# Altium schematic sheet Fly_Module.SchDoc(U_Fly_Module)
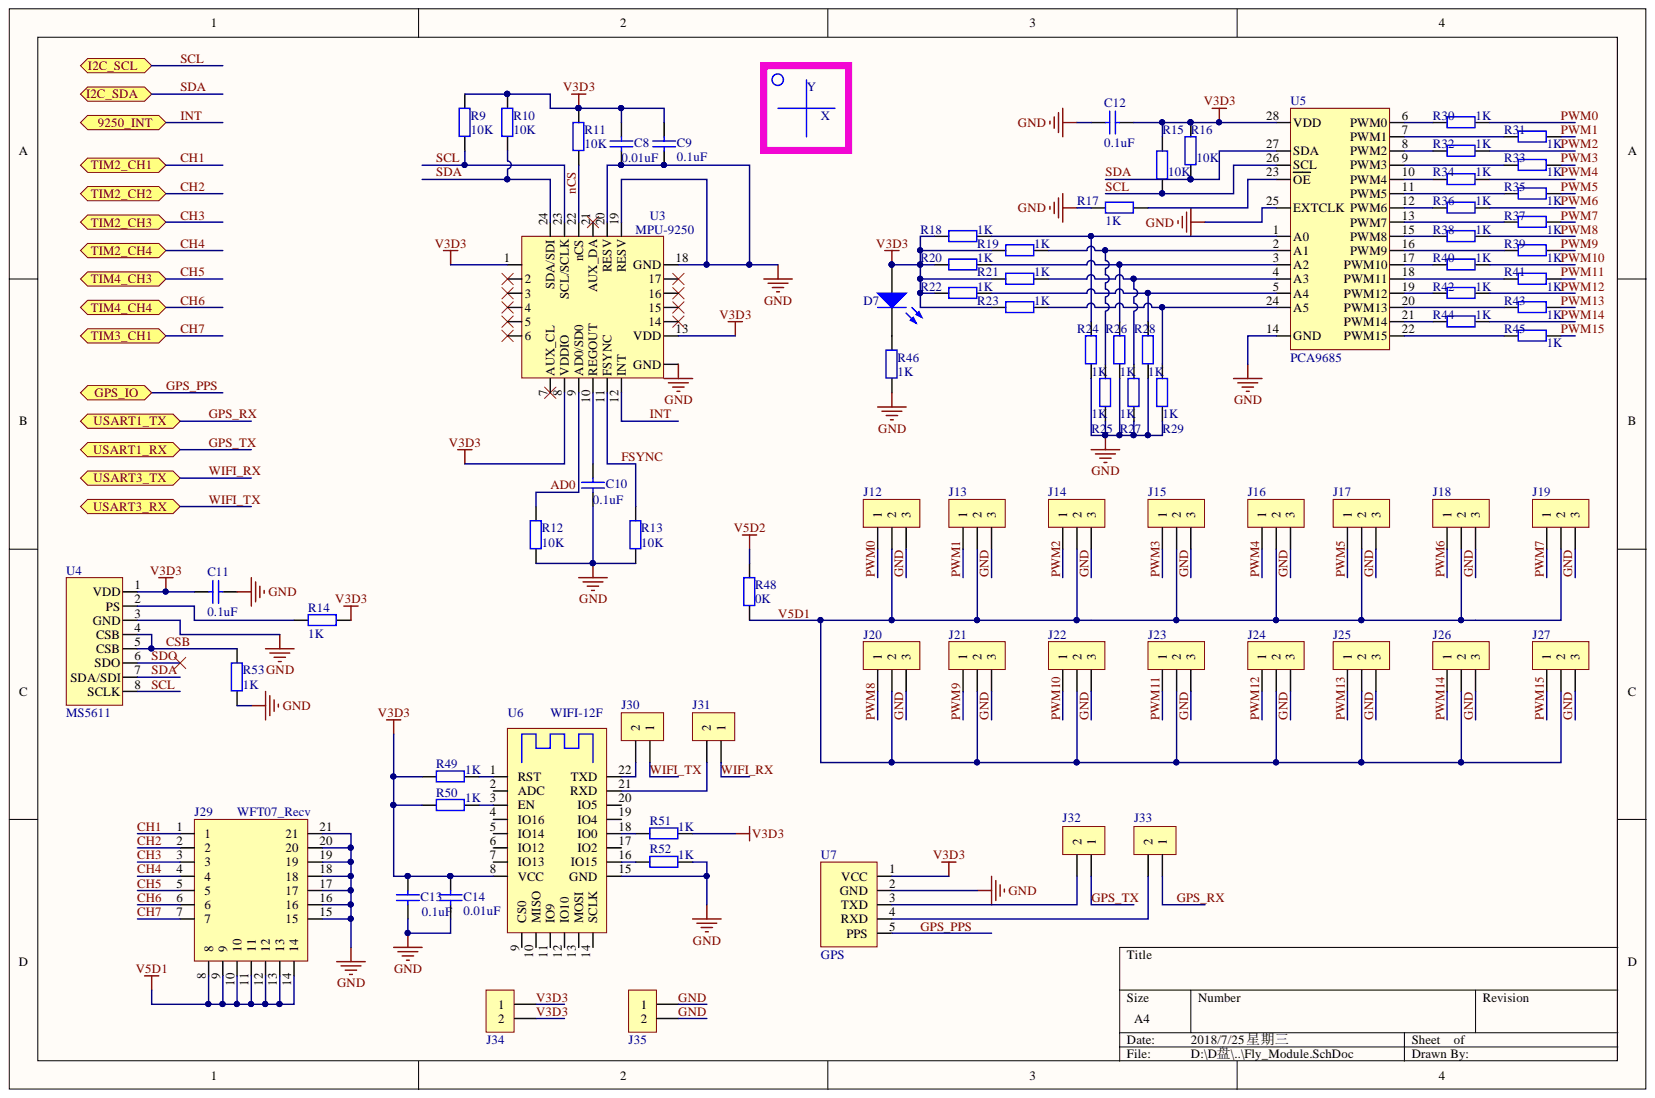
[diagram p0: Fly_Module.SchDoc]

## Parts
C8  value 0.01uF  [0603]  (hidden comment: Cap)
C9  value 0.1uF  [0603]  (hidden comment: Cap)
C10  value 0.1uF  [0603]  (hidden comment: Cap)
C11  value 0.1uF  [0603]  (hidden comment: Cap)
C12  value 0.1uF  [0603]  (hidden comment: Cap)
C13  value 0.1uF  [0603]  (hidden comment: Cap)
C14  value 0.01uF  [0603]  (hidden comment: Cap)
D7  D*  [SIP-2.54-1x2]
J12  Header1X3  [SIP-2.54-1x3]  (value not drawn)
J13  Header1X3  [SIP-2.54-1x3]  (value not drawn)
J14  Header1X3  [SIP-2.54-1x3]  (value not drawn)
J15  Header1X3  [SIP-2.54-1x3]  (value not drawn)
J16  Header1X3  [SIP-2.54-1x3]  (value not drawn)
J17  Header1X3  [SIP-2.54-1x3]  (value not drawn)
J18  Header1X3  [SIP-2.54-1x3]  (value not drawn)
J19  Header1X3  [SIP-2.54-1x3]  (value not drawn)
J20  Header1X3  [SIP-2.54-1x3]  (value not drawn)
J21  Header1X3  [SIP-2.54-1x3]  (value not drawn)
J22  Header1X3  [SIP-2.54-1x3]  (value not drawn)
J23  Header1X3  [SIP-2.54-1x3]  (value not drawn)
J24  Header1X3  [SIP-2.54-1x3]  (value not drawn)
J25  Header1X3  [SIP-2.54-1x3]  (value not drawn)
J26  Header1X3  [SIP-2.54-1x3]  (value not drawn)
J27  Header1X3  [SIP-2.54-1x3]  (value not drawn)
J29  WFT07_Recv  [Header3x7]
J30  Header1X2  [SIP-2.54-1x2]  (value not drawn)
J31  Header1X2  [SIP-2.54-1x2]  (value not drawn)
J32  Header1X2  [SIP-2.54-1x2]  (value not drawn)
J33  Header1X2  [SIP-2.54-1x2]  (value not drawn)
J34  Header1X2  [SIP-2.54-1x2]  (value not drawn)
J35  Header1X2  [SIP-2.54-1x2]  (value not drawn)
R9  R*  value 10K  [0603]
R10  R*  value 10K  [0603]
R11  R*  value 10K  [0603]
R12  R*  value 10K  [0603]
R13  R*  value 10K  [0603]
R14  R*  value 1K  [0603]
R15  R*  value 10K  [0603]
R16  R*  value 10K  [0603]
R17  R*  value 1K  [0603]
R18  R*  value 1K  [0603]
R19  R*  value 1K  [0603]
R20  R*  value 1K  [0603]
R21  R*  value 1K  [0603]
R22  R*  value 1K  [0603]
R23  R*  value 1K  [0603]
R24  R*  value 1K  [0603]
R25  R*  value 1K  [0603]
R26  R*  value 1K  [0603]
R27  R*  value 1K  [0603]
R28  R*  value 1K  [0603]
R29  R*  value 1K  [0603]
R30  R*  value 1K  [0603]
R31  R*  value 1K  [0603]
R32  R*  value 1K  [0603]
R33  R*  value 1K  [0603]
R34  R*  value 1K  [0603]
R35  R*  value 1K  [0603]
R36  R*  value 1K  [0603]
R37  R*  value 1K  [0603]
R38  R*  value 1K  [0603]
R39  R*  value 1K  [0603]
R40  R*  value 1K  [0603]
R41  R*  value 1K  [0603]
R42  R*  value 1K  [0603]
R43  R*  value 1K  [0603]
R44  R*  value 1K  [0603]
R45  R*  value 1K  [0603]
R46  R*  value 1K  [0603]
R48  R*  value 0K  [0603]
R49  R*  value 1K  [0603]
R50  R*  value 1K  [0603]
R51  R*  value 1K  [0603]
R52  R*  value 1K  [0603]
R53  R*  value 1K  [0603]
U3  MPU-9250  [MPU9250]
U4  MS5611  [MS5611]
U5  PCA9685  [PCA9685]
U6  WIFI-12F  [ESP-12F]
U7  GPS  [GPS]

## Ports
9250_INT, GPS_IO, I2C_SCL, I2C_SDA, TIM2_CH1, TIM2_CH2, TIM2_CH3, TIM2_CH4, TIM3_CH1, TIM4_CH3, TIM4_CH4, USART1_RX, USART1_TX, USART3_RX, USART3_TX

## Nets
V5D2: R48.1
AD0: R12.2 U3.9(AD0/SD0)
CH1: J29.1 ; port TIM2_CH1
CH2: J29.2 ; port TIM2_CH2
CH3: J29.3 ; port TIM2_CH3
CH4: J29.4 ; port TIM2_CH4
CH5: J29.5 ; port TIM4_CH3
CH6: J29.6 ; port TIM4_CH4
CH7: J29.7 ; port TIM3_CH1
CSB: R53.2 U4.4(CSB) U4.5(CSB)
FSYNC: R13.2 U3.11(FSYNC)
GND: C8.1 C9.1 C10.1 C11.1 C12.2 C13.2 C14.2 J12.3 J13.3 J14.3 J15.3 J16.3 J17.3 J18.3 J19.3 J20.3 J21.3 J22.3 J23.3 J24.3 J25.3 J26.3 J27.3 J29.15 J29.16 J29.17 J29.18 J29.19 J29.20 J29.21 J35.1 J35.2 R12.1 R13.1 R17.2 R24.2 R25.2 R26.2 R27.2 R28.2 R29.2 R46.2 R52.2 R53.1 U3.0(GND) U3.18(GND) U3.19(RESV) U3.20(RESV) U4.3(GND) U5.14(GND) U5.25(EXTCLK) U6.15(GND) U7.2(GND)
GPS_PPS: U7.5(PPS) ; port GPS_IO
GPS_RX: J33.1 ; port USART1_TX
GPS_TX: J32.1 ; port USART1_RX
INT: U3.12(INT) ; port 9250_INT
nCS: R11.1 U3.22(nCS)
PWM0: J12.1 R30.2
PWM1: J13.1 R31.2
PWM2: J14.1 R32.2
PWM3: J15.1 R33.2
PWM4: J16.1 R34.2
PWM5: J17.1 R35.2
PWM6: J18.1 R36.2
PWM7: J19.1 R37.2
PWM8: J20.1 R38.2
PWM9: J21.1 R39.2
PWM10: J22.1 R40.2
PWM11: J23.1 R41.2
PWM12: J24.1 R42.2
PWM13: J25.1 R43.2
PWM14: J26.1 R44.2
PWM15: J27.1 R45.2
SCL: R9.1 R15.1 U3.23(SCL/SCLK) U4.8(SCLK) U5.26(SCL) ; port I2C_SCL
SDA: R10.1 R16.1 U3.24(SDA/SDI) U4.7(SDA/SDI) U5.27(SDA) ; port I2C_SDA
SDO: U4.6(SDO)
V3D3: C8.2 C9.2 C11.2 C12.1 C13.1 C14.1 D7.1 J34.1 J34.2 R9.2 R10.2 R11.2 R14.1 R15.2 R16.2 R18.1 R19.1 R20.1 R21.1 R22.1 R23.1 R49.1 R50.1 R51.2 U3.1 U3.8(VDDIO) U3.13(VDD) U4.1(VDD) U5.28(VDD) U6.8(VCC) U7.1(VCC)
V5D1: J12.2 J13.2 J14.2 J15.2 J16.2 J17.2 J18.2 J19.2 J20.2 J21.2 J22.2 J23.2 J24.2 J25.2 J26.2 J27.2 J29.8 J29.9 J29.10 J29.11 J29.12 J29.13 J29.14 R48.2
WIFI_RX: J31.1 ; port USART3_TX
WIFI_TX: J30.1 ; port USART3_RX
NetC10_2: C10.2 U3.10(REGOUT)
NetD7_2: D7.2 R46.1
NetJ30_2: J30.2 U6.22(TXD)
NetJ31_2: J31.2 U6.21(RXD)
NetJ32_2: J32.2 U7.3(TXD)
NetJ33_2: J33.2 U7.4(RXD)
NetR14_2: R14.2 U4.2(PS)
NetR17_1: R17.1 U5.23(OE)
NetR18_2: R18.2 R24.1 U5.1(A0)
NetR19_2: R19.2 R25.1 U5.2(A1)
NetR20_2: R20.2 R26.1 U5.3(A2)
NetR21_2: R21.2 R27.1 U5.4(A3)
NetR22_2: R22.2 R28.1 U5.5(A4)
NetR23_2: R23.2 R29.1 U5.24(A5)
NetR30_1: R30.1 U5.6(PWM0)
NetR31_1: R31.1 U5.7(PWM1)
NetR32_1: R32.1 U5.8(PWM2)
NetR33_1: R33.1 U5.9(PWM3)
NetR34_1: R34.1 U5.10(PWM4)
NetR35_1: R35.1 U5.11(PWM5)
NetR36_1: R36.1 U5.12(PWM6)
NetR37_1: R37.1 U5.13(PWM7)
NetR38_1: R38.1 U5.15(PWM8)
NetR39_1: R39.1 U5.16(PWM9)
NetR40_1: R40.1 U5.17(PWM10)
NetR41_1: R41.1 U5.18(PWM11)
NetR42_1: R42.1 U5.19(PWM12)
NetR43_1: R43.1 U5.20(PWM13)
NetR44_1: R44.1 U5.21(PWM14)
NetR45_1: R45.1 U5.22(PWM15)
NetR49_2: R49.2 U6.1(RST)
NetR50_2: R50.2 U6.3(EN)
NetR51_1: R51.1 U6.18(IO0)
NetR52_1: R52.1 U6.16(IO15)

Not connected: U3 2 3 4 5 6 7(AUX_CL) 14 15 16 17 21(AUX_DA); U6 2(ADC) 4(IO16) 5(IO14) 6(IO12) 7(IO13) 9(CS0) 10(MISO) 11(IO9) 12(IO10) 13(MOSI) 14(SCLK) 17(IO2) 19(IO4) 20(IO5)

## Library data of the parts
D7 = LED: Component Kind: Standard; Library Name: MyIntergrateLib.IntLib
J29 = Header3x7: Library Name: Fly_schlib.SchLib; Component Kind: Standard
R9-R46 R48-R53 = Res: Component Kind: Standard; Library Name: MyIntergrateLib.IntLib
U3 = MPU9250: Library Name: relay_sensor.SchLib; Component Kind: Standard
U5 = PCA9685PW: Component Kind: Standard; Library Name: MyIntergrateLib.IntLib
U6 = ESP-12F: Library Name: Fly_schlib.SchLib; Component Kind: Standard
U7 = GPS_MODULE: Library Name: Fly_schlib.SchLib; Component Kind: Standard
C8-C14 = Cap: Component Kind: Standard; Library Name: MyIntergrateLib.IntLib
J12-J27 = Header1X3: Component Kind: Standard; Library Name: MyIntergrateLib.IntLib
J30-J35 = Header1X2: Component Kind: Standard; Library Name: MyIntergrateLib.IntLib
U4 = MS5611: Component Kind: Standard; Library Name: MyIntergrateLib.IntLib
